# Altium schematic sheet 2-Power.SchDoc(2-Power)
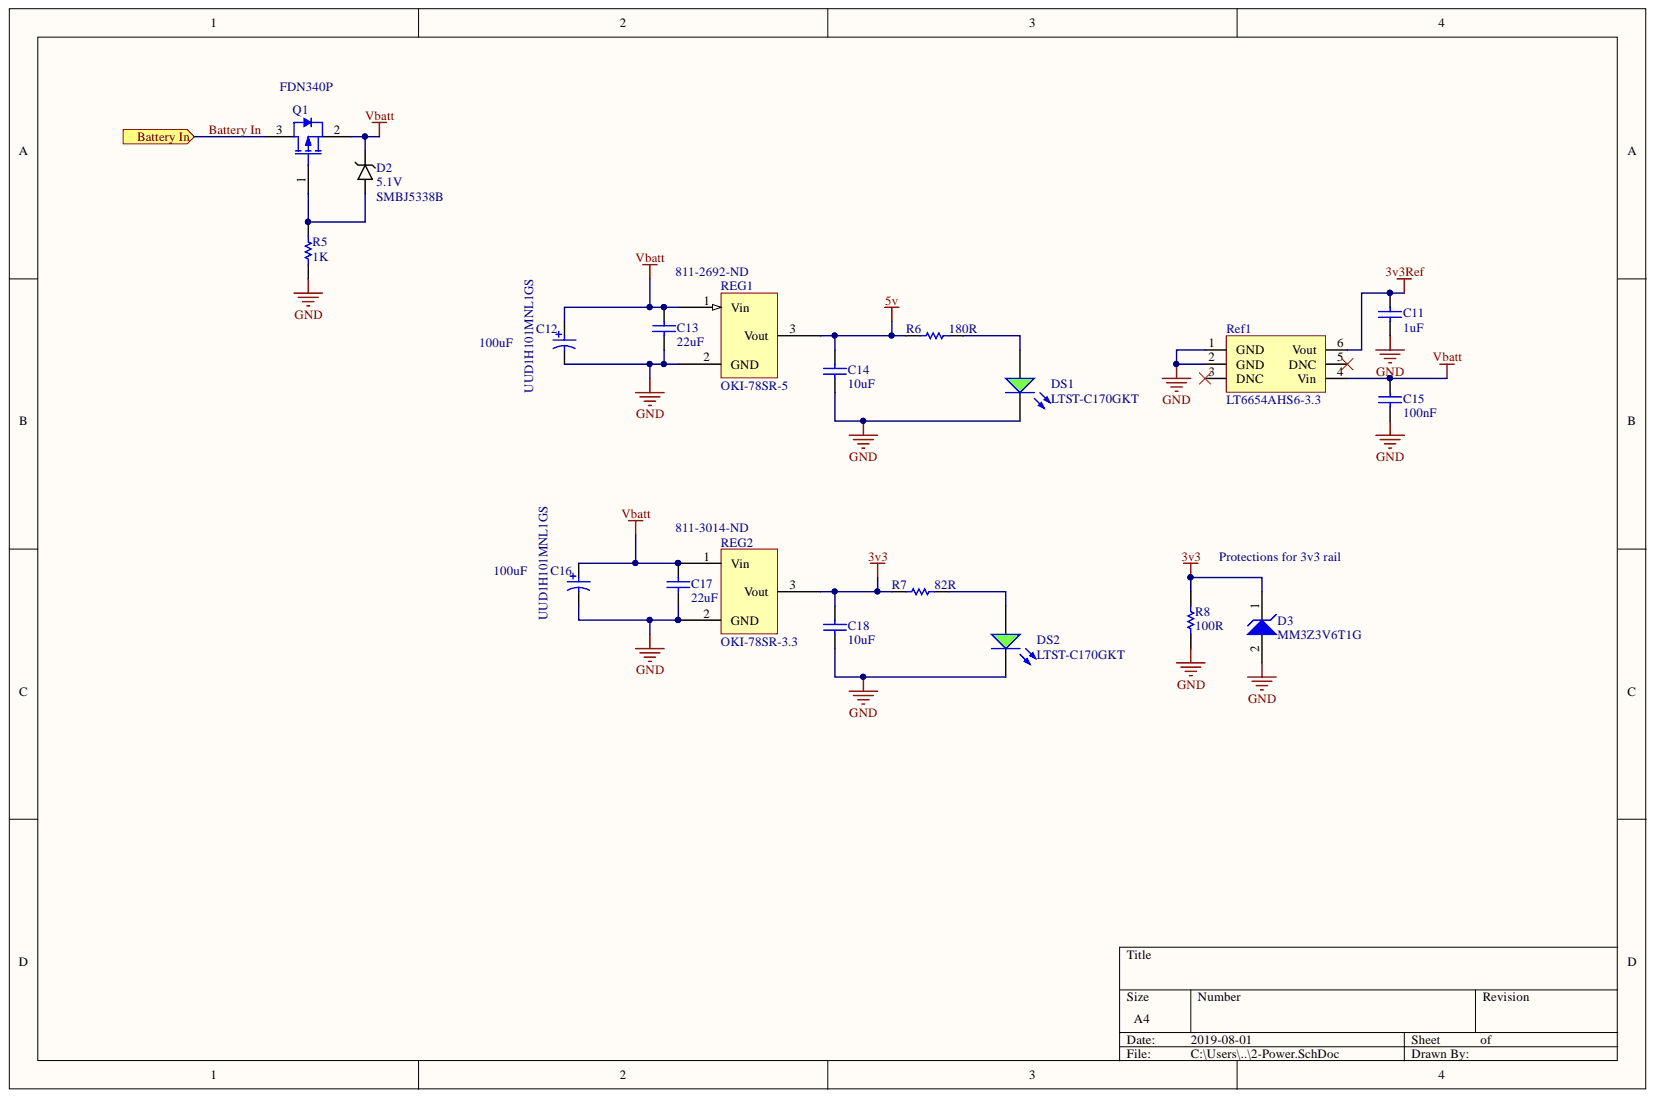
[diagram p0: 2-Power.SchDoc]

## Parts
C11  value 1uF  [1608[0603]]  (hidden comment: Cap)
C12  UUD1H101MNL1GS  [NIC-CAPA2-8X10_V]
C13  value 22uF  [C1210_M]  (hidden comment: Cap)
C14  value 10uF  [C1210_M]  (hidden comment: Cap)
C15  value 100nF  [1608[0603]]  (hidden comment: Cap)
C16  UUD1H101MNL1GS  [NIC-CAPA2-8X10_V]
C17  value 22uF  [C1210_M]  (hidden comment: Cap)
C18  value 10uF  [C1210_M]  (hidden comment: Cap)
D2  Reverse Voltage: 5.1V  Part Number: SMBJ5338B  [DO-214AA (SMB)]  (hidden comment: Zener Diode, 5.1V, 5W SMBJ5338B)
D3  MM3Z3V6T1G  [ONSC-SOD-323-2-477-02_V]
DS1  LTST-C170GKT  [LTST-C170GKT_V]
DS2  LTST-C170GKT  [LTST-C170GKT_V]
Q1  FDN340P  [FAIR-SSOT3L-3_V]
R5  value 1K  [1608[0603]]  (hidden comment: Res1)
R6  value 180R  [1608[0603]]  (hidden comment: Res1)
R7  value 82R  [1608[0603]]  (hidden comment: Res1)
R8  100R  [RESC1206(3216)_M]
Ref1  LT6654AHS6-3.3  [TSOT-23 S6]
REG1  OKI-78SR-5  Supplier Part Number 2: 811-2692-ND  [Reg - TH, 3 pin, 100 mil Pitch, HTL]
REG2  OKI-78SR-3.3  Supplier Part Number 2: 811-3014-ND  [Reg - TH, 3 pin, 100 mil Pitch, VTL]

## Ports
Battery In

## Nets
3v3: C18.2 D3.1 R7.1 R8.2 REG2.3
3v3Ref: C11.2 Ref1.6(Vout)
5v: C14.2 R6.1 REG1.3
GND: C11.1 C12.2 C13.1 C14.1 C15.1 C16.2 C17.1 C18.1 D3.2 DS1.2 DS2.2 R5.1 R8.1 Ref1.1(GND) Ref1.2(GND) REG1.2 REG2.2
Vbatt: C12.1 C13.2 C15.2 C16.1 C17.2 D2.K Q1.2 Ref1.4(Vin) REG1.1 REG2.1
Battery In: Q1.3 ; port Battery In
NetD2_A: D2.A Q1.1 R5.2
NetDS1_1: DS1.1 R6.2
NetDS2_1: DS2.1 R7.2

Not connected: Ref1 3(DNC) 5(DNC)

## Library data of the parts
C11 = Cap: Capacitor; Manufacturer Part Number: GRM188R61E105KA12D; Manufacturer: Murata Electronics North America; Voltage - Rated: 25V; Tolerance: ±10%; Temperature Coefficient: X5R; Capacitance: 1µF; Thickness (Max): 0.035" (0.90mm); Supplier Part Number 1: 490-3897-1-ND; Supplier 1: Digi-Key; Stock 1: 4259407; Size / Dimension: 0.063" L x 0.031" W (1.60mm x 0.80mm); Simulation: CAP; Signal Integrity: Cap; RoHS: RoHS Compliant; Ratings: -; Pricing 1: 1=0.15, 10=0.053, 50=0.0288, 100=0.0242, 250=0.01984, 500=0.01696, 1000=0.0132 (CAD); Part Status: Active; Packaging: Cut Tape (CT); Package / Case: 0603 (1608 Metric); Operating Temperature: -55°C ~ 85°C; Mounting Type: Surface Mount, MLCC; Library Name: Miscellaneous Devices.IntLib; Lead Style: -; Lead Spacing: -; Height - Seated (Max): -; Features: -; ComponentLink1URL: http://www.murata.com/~/media/webrenewal/support/library/catalog/products/capacitor/mlcc/c02e.pdf; ComponentLink1Description: http://www.murata.com/~/media/webrenewal/support/library/catalog/products/capacitor/mlcc/c02e.pdf; Component Kind: Standard; Category: Capacitors; Applications: General Purpose
C12 C16 = CMP-0687-00073-1: Aluminum Electrolytic Capacitor, UD Series, Low Impedance, 100 uF, 50 V, + / - 20%, -55 to 105 degC, Chip Type, 8 x 10 mm D x L, Pb-Free, Reel; Manufacturer: Nichicon; Voltage Rating: 50V; Tolerance Rated Capacitance: -20 to 20%; Surface Mount Land Size: 0.327" L x 0.327" W (8.30mm x 8.30mm); Ripple Current: 300mA; Rated Voltage: 50 V; Rated Ripple Current (at 100kHz): 300 mA; Rated Capacitance: 100 uF; Polarization: Polar; Packing: Dia382mm Reel; Lifetime @ Temp.: 5000 Hrs @ 105°C; Impedance: 0.34 Ohm; Endurance Time: 5000 h; Capacitance: 100µF; Tolerance: ±20%; Supplier Part Number 1: 493-2306-1-ND; Supplier 1: Digi-Key; Stock 1: 65948; Size / Dimension: 0.315" Dia (8.00mm); RoHS: TRUE; Pricing 1: 1=0.92, 10=0.685, 25=0.5868, 50=0.5478, 100=0.4696, 250=0.43044 (CAD); Part Status: Active; PartNumber: UUD1H101MNL1GS; Packaging: Cut Tape (CT); PackageReference: CAPAE-D8H10; PackageDescription: 2-Pin Surface Mount Device, Diameter 8 mm, Height 10 mm, Pitch 6.5 mm; Package / Case: Radial, Can - SMD; Operating Temperature: -55°C ~ 105°C; Mounting Type: Surface Mount; Mounting Technology: Surface Mount; Manufacturer Part Number: UUD1H101MNL1GS; Library Name: Altium Content Vault; Length: 10 mm; Lead Spacing: -; Height - Seated (Max): 0.394" (10.00mm); ESR (Equivalent Series Resistance): -; Diameter: 8 mm; DatasheetVersion: 08/2012; ComponentLink4URL: http://nichicon-us.com/english/products/pdfs/e-uud.pdf; ComponentLink4Description: http://nichicon-us.com/english/products/pdfs/e-uud.pdf; ComponentLink3URL: http://products.nichicon.co.jp/en/pdf/XJA043/e-ud.pdf; ComponentLink3Description: Package Specification; ComponentLink2URL: http://products.nichicon.co.jp/en/pdf/XJA043/e-ud.pdf; ComponentLink2Description: Datasheet; ComponentLink1URL: http://www.nichicon.co.jp/; ComponentLink1Description: Manufacturer URL; Component Kind: Standard; Category: Capacitors; Applications: General Purpose
C13 C17 = Cap: Capacitor; Manufacturer Part Number: CC1210MKX5R8BB226; Manufacturer: Yageo; Voltage - Rated: 25V; Tolerance: ±20%; Temperature Coefficient: X5R; Capacitance: 22µF; Thickness (Max): 0.110" (2.80mm); Supplier Part Number 1: 311-2056-1-ND; Supplier 1: Digi-Key; Stock 1: 128; Size / Dimension: 0.126" L x 0.098" W (3.20mm x 2.50mm); Simulation: CAP; Signal Integrity: Cap; RoHS: RoHS Compliant; Ratings: -; Pricing 1: 1=1, 10=0.703, 25=0.5996, 50=0.5314, 100=0.4628, 250=0.39424 (CAD); Part Status: Active; Packaging: Cut Tape (CT); Package / Case: 1210 (3225 Metric); Operating Temperature: -55°C ~ 85°C; Mounting Type: Surface Mount, MLCC; Library Name: Miscellaneous Devices.IntLib; Lead Style: -; Lead Spacing: -; Height - Seated (Max): -; Features: -; Failure Rate: -; ComponentLink1URL: http://www.yageo.com/documents/recent/UPY-GPHC_X5R_4V-to-50V_23.pdf; ComponentLink1Description: http://www.yageo.com/documents/recent/UPY-GPHC_X5R_4V-to-50V_23.pdf; Component Kind: Standard; Category: Capacitors; Applications: General Purpose
C14 C18 = Cap: Capacitor; Manufacturer Part Number: CC1210ZKY5V8BB106; Manufacturer: Yageo; Voltage - Rated: 25V; Tolerance: -20%, +80%; Temperature Coefficient: Y5V (F); Capacitance: 10µF; Thickness (Max): 0.083" (2.10mm); Supplier Part Number 1: 311-2063-1-ND; Supplier 1: Digi-Key; Stock 1: 1156; Size / Dimension: 0.126" L x 0.098" W (3.20mm x 2.50mm); Simulation: CAP; Signal Integrity: Cap; RoHS: RoHS Compliant; Ratings: -; Pricing 1: 1=0.47, 10=0.323, 25=0.258, 50=0.2154, 100=0.1829, 250=0.14528, 500=0.12912, 1000=0.11298 (CAD); Part Status: Active; Packaging: Cut Tape (CT); Package / Case: 1210 (3225 Metric); Operating Temperature: -30°C ~ 85°C; Mounting Type: Surface Mount, MLCC; Library Name: Miscellaneous Devices.IntLib; Lead Style: -; Lead Spacing: -; Height - Seated (Max): -; Features: -; Failure Rate: -; ComponentLink1URL: http://www.yageo.com/documents/recent/UPY-GPHC_Y5V_6.3V-to-50V_7.pdf; ComponentLink1Description: http://www.yageo.com/documents/recent/UPY-GPHC_Y5V_6.3V-to-50V_7.pdf; Component Kind: Standard; Category: Capacitors; Applications: General Purpose
C15 = Cap: Capacitor; Manufacturer Part Number: CC0603KRX7R9BB104; Manufacturer: Yageo; Voltage - Rated: 50V; Tolerance: ±10%; Temperature Coefficient: X7R; Capacitance: 0.10µF; Thickness (Max): 0.035" (0.90mm); Supplier Part Number 1: 311-1344-1-ND; Supplier 1: Digi-Key; Stock 1: 4016262; Size / Dimension: 0.063" L x 0.031" W (1.60mm x 0.80mm); Simulation: CAP; Signal Integrity: Cap; RoHS: RoHS Compliant; Ratings: -; Pricing 1: 1=0.15, 10=0.043, 25=0.0312, 50=0.0232, 100=0.0189, 250=0.0158, 500=0.01346, 1000=0.01059 (CAD); Part Status: Active; Packaging: Cut Tape (CT); Package / Case: 0603 (1608 Metric); Operating Temperature: -55°C ~ 125°C; Mounting Type: Surface Mount, MLCC; Library Name: Miscellaneous Devices.IntLib; Lead Style: -; Lead Spacing: -; Height - Seated (Max): -; Features: -; Failure Rate: -; ComponentLink1URL: http://www.yageo.com/documents/recent/UPY-GPHC_X7R_6.3V-to-50V_16.pdf; ComponentLink1Description: http://www.yageo.com/documents/recent/UPY-GPHC_X7R_6.3V-to-50V_16.pdf; Component Kind: Standard; Category: Capacitors; Applications: General Purpose
D3 = CMP-1060-00650-1: Zener Voltage Regulator, 300 mW, 2-Pin SOD-323, Pb-Free, Tape and Reel; Manufacturer: On Semiconductor; VZ Typ (V): 3.6; P Max (W): 0.3; RoHS: Pb-Free; PartNumber: MM3Z3V6T1G; Packing: Tape and Reel; PackageVersion: Rev. H, 03/2007; PackageReference: SOD-323-2-477-02; PackageDescription: 2-Pin SOD, Body 1.7 x 1.25 mm; Mounting Technology: Surface Mount; Library Name: Altium Content Vault; DatasheetVersion: Rev. 9; ComponentLink3URL: http://www.onsemi.com/pub_link/Collateral/477-02.PDF.1; ComponentLink3Description: Package Specification; ComponentLink2URL: http://www.onsemi.cn/pub_link/Collateral/MM3Z2V4T1-D.PDF; ComponentLink2Description: Datasheet; ComponentLink1URL: http://www.onsemi.com/; ComponentLink1Description: Manufacturer URL; Component Kind: Standard
DS1 DS2 = CMP-1674-00002-5: LED Uni-Color Green, 100 mW, 120 mA, -55 to 85 degC, 2-Pin SMD, RoHS, Tape and Reel; Manufacturer: Lite-On; Wavelength - Peak: 565nm; Wavelength - Dominant: 569nm; Voltage - Forward (Vf) (Typ): 2.1V; Viewing Angle: 130°; Millicandela Rating: 6mcd; Lens Transparency: Clear; Lens Style/Size: Rectangle with Flat Top, 1.40mm x 1.25mm; Lens Color: Colorless; Current - Test: 10mA; Supplier Part Number 1: 160-1179-1-ND; Supplier Device Package: -; Supplier 1: Digi-Key; Stock 1: 242288; Size / Dimension: 2.00mm L x 1.25mm W; RoHSCompliant: true; RoHS: RoHS Compliant; Pricing 1: 1=0.48, 10=0.351, 25=0.2588, 100=0.1848, 250=0.12564, 500=0.11086, 1000=0.08684 (CAD); Pins: 2; Part Status: Active; Packaging: Tape and Reel; PackageReference: C170GKT; Package Quantity: 1; PackageDescription: 2-Pin Surface Mount Device, Body 2 x 1.25 mm; Package / Case: 0805 (2012 Metric); Mounting Type: Surface Mount; Mounting Technology: Surface Mount; Mount: Surface Mount; Min Operating Temperature: -55 degC; Max Operating Temperature: 85 degC; Manufacturer Part Number: LTST-C170GKT; Library Name: Altium Content Vault; Length: 2 mm; Height (Max): 1.10mm; Height: 1.1 mm; Features: -; DatasheetVersion: 03/2003; ComponentLink2URL: http://media.digikey.com/pdf/Data%20Sheets/Lite-On%20PDFs/LTST-C170GKT.pdf; ComponentLink2Description: Datasheet; ComponentLink1URL: http://www.us.liteon.com/; ComponentLink1Description: Manufacturer URL; Component Kind: Standard; Colour: Green; Color: Green; Category: Optoelectronics
Q1 = CMP-2100-03638-1: Single P-Channel, Logic Level, PowerTrench(R) MOSFET, 2 A, 20 V, -55 to 150 degC, 3-Pin SOT-23, RoHS, Tape and Reel; Manufacturer: Fairchild Semiconductor; Voltage Rating (DC): -20V; Turn-On Delay Time: 10ns; Turn-Off Delay Time: 27ns; Threshold Voltage: -800mV; Rise Time: 9ns; Rds On Max: 70mOhm; Power Dissipation: 500mW; Package Version: Rev. 3, 05/2015; Number of Elements: 1; Input Capacitance: 779pF; Gate to Source Voltage (Vgs): 8V; Fall Time: 11ns; Drain to Source Voltage (Vdss): 20V; Drain to Source Resistance: 60mOhm; Current Rating: -2A; Continuous Drain Current (ID): 2A; Width: 3.05mm; Termination: SMD/SMT; RoHSCompliant: true; REACH SVHC: NoSVHC; Radiation Hardening: No; Packaging: TapeandReel; Package URL: https://www.fairchildsemi.com/package-drawings/MA/MA03B.pdf; Package Reference: SSOT3L; Package Quantity: 1; Package Description: 3-Pin Small Outline Transistor, Body 2.92 x 1.4 mm, Pitch 0.95 mm; Number of Pins: 3; Mount: SurfaceMount; Min Operating Temperature: -55degC; Max Power Dissipation: 500mW; Max Operating Temperature: 150degC; Manufacturer URL: https://www.fairchildsemi.com/; Library Name: Altium Content Vault; Length: 2.92mm; Lead Free: LeadFree; Height: 0.94mm; Element Configuration: Single; Drain to Source Breakdown Voltage: -20V; Datasheet Version: Rev. E1, 02/2007; Datasheet URL: https://www.fairchildsemi.com/datasheets/FD/FDN340P.pdf; Contact Plating: Tin; Component Kind: Standard; Case/Package: SOT-23
R5 = Res1: Resistor; Manufacturer Part Number: ERJ-PA3F1001V; Manufacturer: Panasonic Electronic Components; Tolerance: ±1%; Temperature Coefficient: ±100ppm/°C; Power (Watts): 0.25W, 1/4W; Supplier Part Number 1: P1.0KBYCT-ND; Supplier Device Package: 0603; Supplier 1: Digi-Key; Stock 1: 56110; Size / Dimension: 0.063" L x 0.031" W (1.60mm x 0.80mm); Simulation: RESISTOR; Signal Integrity: Res; RoHS: RoHS Compliant; Resistance (Ohms): 1k; Pricing 1: 1=0.22, 10=0.198, 25=0.138, 50=0.1072, 100=0.0765, 250=0.05972, 500=0.04746, 1000=0.03368 (CAD); Part Status: Active; Packaging: Cut Tape (CT); Package / Case: 0603 (1608 Metric); Operating Temperature: -55°C ~ 155°C; Number of Terminations: 2; Library Name: Miscellaneous Devices.IntLib; Height - Seated (Max): 0.022" (0.55mm); Features: Automotive AEC-Q200, Pulse Withstanding; Failure Rate: -; Composition: Thick Film; ComponentLink1URL: https://industrial.panasonic.com/cdbs/www-data/pdf/RDA0000/AOA0000C243.pdf; ComponentLink1Description: https://industrial.panasonic.com/cdbs/www-data/pdf/RDA0000/AOA0000C243.pdf; Component Kind: Standard; Category: Resistors
R6 = Res1: Resistor; Manufacturer Part Number: RC0603JR-07180RL; Manufacturer: Yageo; Tolerance: ±5%; Temperature Coefficient: ±100ppm/°C; Resistance (Ohms): 180; Power (Watts): 0.1W, 1/10W; Supplier Part Number 1: 311-180GRCT-ND; Supplier Device Package: 0603; Supplier 1: Digi-Key; Stock 1: 201936; Size / Dimension: 0.063" L x 0.031" W (1.60mm x 0.80mm); Simulation: RESISTOR; Signal Integrity: Res; RoHS: RoHS Compliant; Pricing 1: 1=0.16, 10=0.016, 25=0.0112, 50=0.0084, 100=0.0062, 250=0.0048, 500=0.00382, 1000=0.00281 (CAD); Part Status: Active; Packaging: Cut Tape (CT); Package / Case: 0603 (1608 Metric); Operating Temperature: -55°C ~ 155°C; Number of Terminations: 2; Library Name: Miscellaneous Devices.IntLib; Height - Seated (Max): 0.022" (0.55mm); Features: Moisture Resistant; Failure Rate: -; Composition: Thick Film; ComponentLink1URL: http://www.yageo.com/NewPortal/yageodocoutput?fileName=/pdf/R-Chip/PYu-RC_Group_51_RoHS_L_4.pdf; ComponentLink1Description: http://www.yageo.com/NewPortal/yageodocoutput?fileName=/pdf/R-Chip/PYu-RC_Group_51_RoHS_L_4.pdf; Component Kind: Standard; Category: Resistors
R7 = Res1: Resistor; Manufacturer Part Number: RC0603JR-0782RL; Manufacturer: Yageo; Tolerance: ±5%; Temperature Coefficient: ±100ppm/°C; Resistance (Ohms): 82; Power (Watts): 0.1W, 1/10W; Supplier Part Number 1: 311-82GRCT-ND; Supplier Device Package: 0603; Supplier 1: Digi-Key; Stock 1: 72049; Size / Dimension: 0.063" L x 0.031" W (1.60mm x 0.80mm); Simulation: RESISTOR; Signal Integrity: Res; RoHS: RoHS Compliant; Pricing 1: 1=0.16, 10=0.016, 25=0.0112, 50=0.0084, 100=0.0062, 250=0.0048, 500=0.00382, 1000=0.00281 (CAD); Part Status: Active; Packaging: Cut Tape (CT); Package / Case: 0603 (1608 Metric); Operating Temperature: -55°C ~ 155°C; Number of Terminations: 2; Library Name: Miscellaneous Devices.IntLib; Height - Seated (Max): 0.022" (0.55mm); Features: Moisture Resistant; Failure Rate: -; Composition: Thick Film; ComponentLink1URL: http://www.yageo.com/NewPortal/yageodocoutput?fileName=/pdf/R-Chip/PYu-RC_Group_51_RoHS_L_4.pdf; ComponentLink1Description: http://www.yageo.com/NewPortal/yageodocoutput?fileName=/pdf/R-Chip/PYu-RC_Group_51_RoHS_L_4.pdf; Component Kind: Standard; Category: Resistors
R8 = CMP-1014-00050-1: 100R 0.25W 5% 1206 (3216 Metric)  SMD; Value: 100.00 Ohm; Case-Metric: 3216; Case-EIA: 1206; Tolerance: 5 %; Technology: SMT; Power: 0.25 W; Library Name: Altium Content Vault; Component Kind: Standard
Ref1 = LT6654AHS6-3.3: Manufacturer: Linear Technology; Voltage - Output (Min/Fixed): 3.3V; Voltage - Input: 3.8 V ~ 36 V; Tolerance: ±0.05%; Temperature Coefficient: 10ppm/°C; Reference Type: Series; Output Type: Fixed; Noise - 10Hz to 10kHz: 2ppmrms; Noise - 0.1Hz to 10Hz: 1.7ppmp-p; Current - Supply: 600µA; Current - Output: 10mA; Voltage - Output (Max): -; Supplier Part Number 1: LT6654AHS6-3.3#TRMPBFCT-ND; Supplier Device Package: TSOT-23-6; Supplier 1: Digi-Key; Stock 1: 1315; RoHS: RoHS Compliant; Pricing 1: 1=9.53, 25=8.508, 100=5.5596, 250=5.1048 (CAD); Part Status: Active; Packaging: Cut Tape (CT); Package / Case: SOT-23-6 Thin, TSOT-23-6; Operating Temperature: -40°C ~ 125°C (TA); Mounting Type: Surface Mount; Manufacturer Part Number: LT6654AHS6-3.3; Digikey Part Number: LT6654AHS6-3.3#TRMPBFCT-ND; Digikey Link: http://www.digikey.com/product-detail/en/linear-technology/LT6654AHS6-3.3-TRMPBF/LT6654AHS6-3.3-TRMPBFCT-ND/2485839; Current - Cathode: -; ComponentLink1URL: http://www.linear.com/docs/29677; ComponentLink1Description: http://www.linear.com/docs/29677; Component Kind: Standard; Category: Integrated Circuits (ICs); Library Name: VCU Library.SchLib
REG1 = OKI-78SR-5: Manufacturer Part Number: OKI-78SR-3.3/1.5-W36-C; Manufacturer: Murata Power Solutions Inc.; Voltage - Output 1: 3.3V; Voltage - Input (Min): 7V; Voltage - Input (Max): 36V; Power (Watts) - Max: 5W; Number of Outputs: 1; Efficiency: 85.5%; Current - Output (Max): 1.5A; Voltage - Output 3: -; Voltage - Output 2: -; Voltage - Isolation: -; Type: Linear Regulator Replacement; Supplier Part Number 1: 811-2195-5-ND; Supplier 2: Digi-Key; Supplier 1: Digi-Key; Stock 2: 10314; Stock 1: 3616; Size / Dimension: 0.41" L x 0.30" W x 0.65" H (10.4mm x 7.6mm x 16.5mm); RoHS: RoHS Compliant; Pricing 2: 1=6.31, 5=6.248, 10=6.205, 25=6.1168, 50=5.9262, 100=5.5449, 250=5.28716 (CAD); Pricing 1: 1=6.23, 5=6.174, 10=6.132, 25=6.0444, 50=5.856, 100=5.4792, 250=5.22456 (CAD); Power (Watts) - Manufacture Series: 5W; Part Status: Active; Packaging: Tube; Package / Case: 3-SIP Module; Operating Temperature: -40°C ~ 85°C; Mounting Type: Through Hole; Library Name: FE Schem Lib.SchLib; Features: SCP; ComponentLink2URL: http://power.murata.com/data/power/oki-78sr.pdf; ComponentLink2Description: http://power.murata.com/data/power/oki-78sr.pdf; ComponentLink1URL: http://www.murata-ps.com/data/power/oki-78sr.pdf; ComponentLink1Description: http://www.murata-ps.com/data/power/oki-78sr.pdf; Component Kind: Standard; Category: Power Supplies - Board Mount; Applications: ITE (Commercial)
REG2 = OKI-78SR-5: 3.3V DCDC; Power (Watts) - Manufacture Series: 5W; Manufacturer Part Number: OKI-78SR-3.3/1.5-W36H-C; Manufacturer: Murata Power Solutions Inc.; Voltage - Input (Min): 7V; Voltage - Input (Max): 36V; Number of Outputs: 1; Efficiency: 85.5%; Current - Output (Max): 1.5A; Voltage - Output 3: -; Voltage - Output 2: -; Voltage - Output 1: 3.3V; Voltage - Isolation: -; Type: Linear Regulator Replacement; Supplier Part Number 1: 811-2196-5-ND; Supplier 2: Digi-Key; Supplier 1: Digi-Key; Stock 2: 4108; Size / Dimension: 0.65" L x 0.41" W x 0.30" H (16.5mm x 10.4mm x 7.6mm); RoHS: RoHS Compliant; Pricing 2: 1=6.31, 5=6.248, 10=6.205, 25=6.1168, 50=5.9262, 100=5.5449, 250=5.28716 (CAD); Part Status: Active; Packaging: Tray; Package / Case: 3-SIP Module; Operating Temperature: -40°C ~ 85°C; Mounting Type: Through Hole; Library Name: FE Schem Lib.SchLib; Features: SCP; ComponentLink1URL: http://power.murata.com/data/power/oki-78sr.pdf; ComponentLink1Description: http://power.murata.com/data/power/oki-78sr.pdf; Component Kind: Standard; Category: Power Supplies - Board Mount; Applications: ITE (Commercial)
D2 = Zener Diode, 5.1V, 5W SMBJ5338B: Power: 5W; Component Kind: Standard; Library Name: VCU Library.SchLib
